# Altium schematic sheet Amplifier.SchDoc(Amplifier)
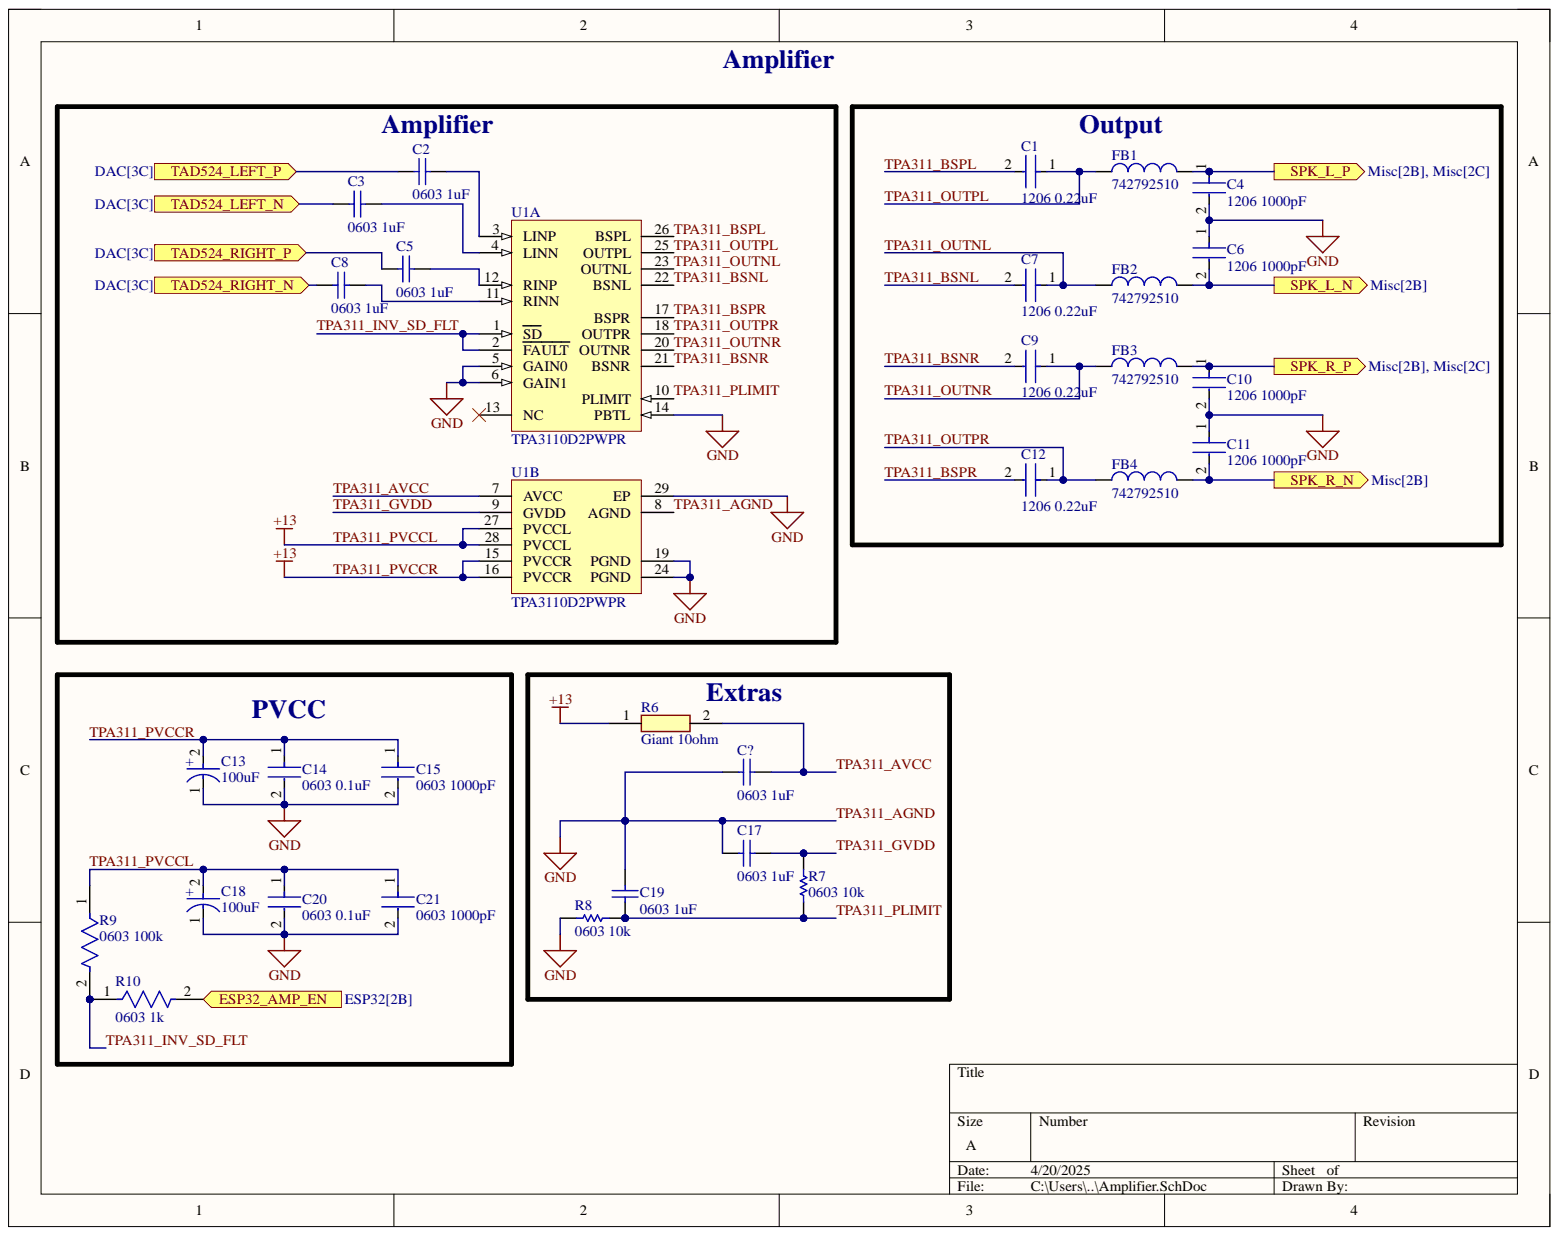
[diagram p0: Amplifier.SchDoc]

## Parts
C1  1206 0.22uF  [FP-C1206C-EB-MFG]
C2  0603 1uF  [CAPC1608X87X35LL15T15]
C3  0603 1uF  [CAPC1608X87X35LL15T15]
C4  1206 1000pF  [FP-C1206C-EB-MFG]
C5  0603 1uF  [CAPC1608X87X35LL15T15]
C6  1206 1000pF  [FP-C1206C-EB-MFG]
C7  1206 0.22uF  [FP-C1206C-EB-MFG]
C8  0603 1uF  [CAPC1608X87X35LL15T15]
C9  1206 0.22uF  [FP-C1206C-EB-MFG]
C10  1206 1000pF  [FP-C1206C-EB-MFG]
C11  1206 1000pF  [FP-C1206C-EB-MFG]
C12  1206 0.22uF  [FP-C1206C-EB-MFG]
C13  100uF  [FP-RAD-TH-D_8_0_5-L_11_5-MFG]
C14  0603 0.1uF  [FP-C0603C-CF-MFG]
C15  0603 1000pF  [FP-C0603C-CF-MFG]
C17  0603 1uF  [CAPC1608X87X35LL15T15]
C18  100uF  [FP-RAD-TH-D_8_0_5-L_11_5-MFG]
C19  0603 1uF  [CAPC1608X87X35LL15T15]
C20  0603 0.1uF  [FP-C0603C-CF-MFG]
C21  0603 1000pF  [FP-C0603C-CF-MFG]
C?  0603 1uF  [CAPC1608X87X35LL15T15]
FB1  742792510  [WE-CBF_1812_L4.5W3.2H1.5_HC]
FB2  742792510  [WE-CBF_1812_L4.5W3.2H1.5_HC]
FB3  742792510  [WE-CBF_1812_L4.5W3.2H1.5_HC]
FB4  742792510  [WE-CBF_1812_L4.5W3.2H1.5_HC]
R6  Giant 10ohm  [TO508P350X1040X2030-2P]
R7  0603 10k  Case Code (Imperial): 0603  [RESC1609X55X30LL15T15]
R8  0603 10k  Case Code (Imperial): 0603  [RESC1609X55X30LL15T15]
R9  0603 100k  Case Code (Imperial): 0603  [FP-RC0603-0_55-MFG]
R10  0603 1k  Case Code (Imperial): 0603  [FP-AC0603-IPC_B]
U1  TPA3110D2PWPR  [TI-PWP28-5720X1950TP_L]  (parts A,B)

## Ports
ESP32_AMP_EN, SPK_L_N, SPK_L_P, SPK_R_N, SPK_R_P, TAD524_LEFT_N, TAD524_LEFT_P, TAD524_RIGHT_N, TAD524_RIGHT_P

## Nets
ESP32_AMP_EN: R10.2 ; port ESP32_AMP_EN
SPK_L_N: C6.2 FB2.2 ; port SPK_L_N
SPK_L_P: C4.1 FB1.2 ; port SPK_L_P
SPK_R_N: C11.2 FB4.2 ; port SPK_R_N
SPK_R_P: C10.1 FB3.2 ; port SPK_R_P
TAD524_LEFT_N: C3.1 ; port TAD524_LEFT_N
TAD524_LEFT_P: C2.1 ; port TAD524_LEFT_P
TAD524_RIGHT_N: C8.1 ; port TAD524_RIGHT_N
TAD524_RIGHT_P: C5.1 ; port TAD524_RIGHT_P
TPA311_AGND: C4.2 C6.1 C10.2 C11.1 C13.1 C14.2 C15.2 C17.1 C18.1 C19.1 C20.2 C21.2 C?.1 R8.1 U1.5(GAIN0) U1.6(GAIN1) U1.8(AGND) U1.14(PBTL) U1.19(PGND) U1.24(PGND) U1.29(EP)
TPA311_AVCC: C?.2 R6.2 U1.7(AVCC)
TPA311_BSNL: C7.2 U1.22(BSNL)
TPA311_BSNR: C9.2 U1.21(BSNR)
TPA311_BSPL: C1.2 U1.26(BSPL)
TPA311_BSPR: C12.2 U1.17(BSPR)
TPA311_GVDD: C17.2 R7.1 U1.9(GVDD)
TPA311_INV_SD_FLT: R9.2 R10.1 U1.1(SD) U1.2(FAULT)
TPA311_OUTNL: C7.1 FB2.1 U1.23(OUTNL)
TPA311_OUTNR: C9.1 FB3.1 U1.20(OUTNR)
TPA311_OUTPL: C1.1 FB1.1 U1.25(OUTPL)
TPA311_OUTPR: C12.1 FB4.1 U1.18(OUTPR)
TPA311_PLIMIT: C19.2 R7.2 R8.2 U1.10(PLIMIT)
TPA311_PVCCL: C13.2 C14.1 C15.1 C18.2 C20.1 C21.1 R6.1 R9.1 U1.15(PVCCR) U1.16(PVCCR) U1.27(PVCCL) U1.28(PVCCL)
NetC2_2: C2.2 U1.3(LINP)
NetC3_2: C3.2 U1.4(LINN)
NetC5_2: C5.2 U1.12(RINP)
NetC8_2: C8.2 U1.11(RINN)

Not connected: U1 13(NC)

## Library data of the parts
C1 C7 C9 C12 = CMP-03020-014280-1: CAP CER 0.22UF 50V X7R 1206; Manufacturer: KEMET; Manufacturer Part Number: C1206C224K5RACTU; Automotive Grade: Grade 0; Capacitance: 0.22 μF; Material: Ceramic; Temperature Coefficient: 15%; Tolerance: 0.1; Value: 0.22 μF; Automotive: Yes; Category: Cap; Component Kind: Standard; ComponentLink2Description: Datasheet; ComponentLink2URL: https://content.kemet.com/datasheets/KEM_C1007_X8R_ULTRA_150C_SMD.pdf; Device Class L1: Passive Components; Device Class L2: Capacitors; Device Class L3: Ceramic Capacitors; Digikey Description: CAP CER 0.22UF 50V X7R 1206; Height: 0.88mm; Ipc Land Pattern Name: CAPC320160X78; Lead Free: Yes; Library Name: Altium Content Vault; Octopart: https://octopart.com/c1206c224k5ractu-kemet-99129; Package: C1206C-EB; RoHS: TRUE; Temperature: -55°C to +150°C; Temperature Characteristic: X7R; Temperature Range High: +150°C; Temperature Range Low: -55°C; Voltage Rating: 50 V
C2 C3 C5 C8 C17 C19 C? = CMP-2006-03713-1: Manufacturer: Kemet; Part Number: C0603C105K3RACTU; Component Kind: Standard; Library Name: Altium Content Vault
C4 C6 C10 C11 = CMP-03020-011698-1: CAP CER 100PF 100V C0G/NP0 1206; Manufacturer: KEMET; Manufacturer Part Number: C1206C101J1GACTU; Automotive Grade: Grade 0; Material: Ceramic; Temperature Characteristic: C0G; Temperature Coefficient: 15%; Tolerance: 0.05; Automotive: Yes; Capacitance: 100 pF; Category: Cap; Component Kind: Standard; ComponentLink2Description: Datasheet; ComponentLink2URL: https://content.kemet.com/datasheets/KEM_C1007_X8R_ULTRA_150C_SMD.pdf; Device Class L1: Passive Components; Device Class L2: Capacitors; Device Class L3: Ceramic Capacitors; Digikey Description: CAP CER 100PF 100V C0G/NP0 1206; Height: 0.88mm; Ipc Land Pattern Name: CAPC320160X78; Lead Free: Yes; Library Name: Altium Content Vault; Mouser Description: Multilayer Ceramic Capacitors MLCC - SMD/SMT 100volts 100pF C0G 5%; Octopart: https://octopart.com/c1206c101j1gactu-kemet-98729; Package: C1206C-EB; RoHS: TRUE; Temperature: -55°C to +150°C; Temperature Range High: +150°C; Temperature Range Low: -55°C; Value: 100 pF; Voltage Rating: 100 V
C13 C18 = CMP-05427-002079-1: Aluminum Electrolytic Capacitors (Radial Lead Type) 100uF ±20% 50V; ESR (Equivalent Series Resistance): 61mR; Lead Diameter: 0.6mm; Lead/Base Style: Straight; Life (Hours): 6000Hour; Ripple Current: 870mA; Capacitance: 100uF; Case/Package: Radial; Component Kind: Standard; Construction: RADIAL; Contact Plating: Tin; Diameter: 8mm; Height: 11.5mm; Height - Seated (Max): 0.512inch; Impedance: 61mR; Lead Pitch: 3.5mm; Length: 11.5mm; Library Name: Altium Content Vault; Max Operating Temperature: 105°C; Min Operating Temperature: -40°C; Mount: Through Hole; Number of Pins: 2; Package Quantity: 200; Packaging: Bulk; Radiation Hardening: No; REACH SVHC: No SVHC; Ripple Current (AC): 870mA; RoHS Compliant: Yes; Series: FR; Termination: Radial; Tolerance: 20%; Voltage: 50V; Voltage Rating: 50V; Voltage Rating (DC): 50V
C14 C20 = CMP-2006-03057-2: CAP CER 0.1UF 25V X7R 0603; Manufacturer: KEMET; Manufacturer Part Number: C0603C104K3RACTU; Automotive Grade: Grade 1; Capacitance: 0.10 μF; Material: Ceramic; Temperature Coefficient: 30ppm/°C; Tolerance: 0.1; Value: 0.10 μF; Automotive: Yes; Category: Cap; Component Kind: Standard; ComponentLink2Description: Datasheet; ComponentLink2URL: https://api.kemet.com/component-edge/download/datasheet/C0603C100J5GACAUTO.pdf; Device Class L1: Passive Components; Device Class L2: Capacitors; Device Class L3: Ceramic Capacitors; Digikey Description: CAP CER 0.1UF 25V X7R 0603; Height: 0.87mm; Lead Free: Yes; Library Name: Altium Content Vault; Mouser Description: Multilayer Ceramic Capacitors MLCC - SMD/SMT 25volts 0.1uF X7R 10%; Octopart: https://octopart.com/c0603c104k3ractu-kemet-127541; Package: C0603C-CF; RoHS: TRUE; Temperature: -55°C to +125°C; Temperature Characteristic: X7R; Temperature Range High: +125°C; Temperature Range Low: -55°C; Voltage Rating: 25 V
C15 C21 = CMP-2006-03010-2: CAP CER 1000PF 25V 5% NP0 0603; Manufacturer: KEMET; Manufacturer Part Number: C0603C102J3GACTU; Automotive Grade: Grade 1; Capacitance: 1,000 pF; Material: Ceramic; Temperature Characteristic: C0G; Temperature Coefficient: 30ppm/°C; Tolerance: 0.05; Value: 1,000 pF; Automotive: Yes; Category: Cap; Component Kind: Standard; ComponentLink2Description: Datasheet; ComponentLink2URL: https://api.kemet.com/component-edge/download/datasheet/C0603C100J5GACAUTO.pdf; Device Class L1: Passive Components; Device Class L2: Capacitors; Device Class L3: Ceramic Capacitors; Digikey Description: CAP CER 1000PF 25V 5% NP0 0603; Height: 0.87mm; Lead Free: Yes; Library Name: Altium Content Vault; Mouser Description: Multilayer Ceramic Capacitors MLCC - SMD/SMT 25volts 1000pF C0G 5%; Octopart: https://octopart.com/c0603c102j3gactu-kemet-8375722; Package: C0603C-CF; RoHS: TRUE; Temperature: -55°C to +125°C; Temperature Range High: +125°C; Temperature Range Low: -55°C; Voltage Rating: 25 V
FB1-FB4 = CMP-0220-00085-2: NexarPartId: 7861697; Current Rating: 6A; DC Resistance (DCR): 30mΩ; Impedance: 70Ω; Material: Ferrite; Max DC Current: 3mA; Number of Lines: 1; Test Frequency: 100MHz; Tolerance: 25%; Case Code (Imperial): 1812; Case Code (Metric): 4532; Case/Package: SMD/SMT; Component Kind: Standard; Depth: 3.2mm; Height: 1.5mm; Lead Free: Lead Free; Length: 4.5mm; Library Name: Altium Content Vault; Max Current Rating: 6A; Max Operating Temperature: 125°C; Min Operating Temperature: -55°C; Mount: Surface Mount; Packaging: Cut Tape (CT); REACH SVHC: Unknown; Resistance: 30mΩ; RoHS: Compliant; Termination: SMD/SMT; Weight: 112.008966mg; Width: 3.2mm
R6 = LTO050F10R00JTE3: Resistor; Manufacturer_Name: Vishay; Arrow Part Number: LTO050F10R00JTE3; Arrow Price/Stock: https://www.arrow.com/en/products/lto050f10r00jte3/vishay?region=nac; Component Kind: Standard; Datasheet Link: https://componentsearchengine.com/Datasheets/2/LTO050F10R00JTE3.pdf; Height: 3.5mm; Manufacturer_Part_Number: LTO050F10R00JTE3; Mouser Part Number: 72-LTO050F10R00JTE3; Mouser Price/Stock: https://www.mouser.co.uk/ProductDetail/Vishay-Sfernice/LTO050F10R00JTE3?qs=YEvEAkYMKXtbfJC7MrgrfA%3D%3D
R7 R8 = CMP-1012-00623-3: Chip Resistor, 10 KOhm, +/- 1%, 0.1 W, -55 to 155 degC, 0603 (1608 Metric), RoHS, Tape and Reel; Manufacturer: Panasonic; Max Power Dissipation: 100mW; Resistance: 10KOhm; Temperature Coefficient: 100ppm\/degreesC; Voltage Rating: 75V; Case Code (Metric): 1608; Case\/Package: 0603; Component Kind: Standard; Composition: ThickFilm; Contact Plating: Tin; Datasheet URL: https://industrial.panasonic.com/cdbs/www-data/pdf/RDA0000/AOA0000C304.pdf; Datasheet Version: 02/2017; Depth: 0.8mm; Height: 0.45mm; Lead Free: LeadFree; Length: 1.6mm; Library Name: Altium Content Vault; Manufacturer URL: http://www.panasonic.com/; Max Operating Temperature: 155degC; Military Standard: Not; Min Operating Temperature: -55degC; Mount: SurfaceMount; Mounting Technology: SurfaceMount; Number of Pins: 2; Number of Terminations: 2; Package Description: 2-Pin Surface Mount Device, Body 1.6 x 0.85 mm; Package Quantity: 1; Package Reference: 603; Packaging: TapeandReel; Power Rating: 100mW; Radiation Hardening: No; REACH SVHC: NoSVHC; RoHSCompliant: true; Termination: SMD/SMT; Tolerance: 1%; Voltage Rating (DC): 75V; Width: 0.031inch
R9 = CMP-2100-03662-2: RES SMD 100K OHM 1% 1/10W 0603; Max Power Dissipation: 100mW; Resistance: 100kR; Temperature Coefficient: 100ppm/°C; Voltage Rating: 50V; Working Voltage: 75V; Case Code (Metric): 1608; Case/Package: 0603; Component Kind: Standard; Construction: Rectangular; Depth: 0.8mm; Features: Moisture Resistant; Height: 0.45mm; Lead Free: Lead Free; Length: 1.6mm; Library Name: Altium Content Vault; Max Operating Temperature: 155°C; Military Standard: Not; Min Operating Temperature: -55°C; Number of Pins: 2; Number of Terminations: 2; Packaging: Tape and Reel; Power Rating: 100mW; REACH SVHC: No SVHC; Resistance Tolerance: 1%; Resistor Type: Thick Film; RoHS Compliant: Yes; Series: RC; Technology: THICK FILM; Termination: SMD/SMT; Tolerance: 1%; Voltage Rating (DC): 50V; Width: 0.8mm
R10 = CMP-2000-04828-3: RES 1kΩ 1.00% 0.1W 0603; Max Power Dissipation: 100mW; Resistance: 1kR; Temperature Coefficient: 100ppm/°C; Tolerance: 1%; Voltage Rating: 50V; Case Code (Metric): 1608; Case/Package: 0603; Component Kind: Standard; Construction: Rectangular; Depth: 0.8mm; Features: Moisture Resistant; Height: 0.022inch; Lead Free: Lead Free; Length: 0.063inch; Library Name: Altium Content Vault; Max Operating Temperature: 155°C; Military Standard: Not; Min Operating Temperature: -55°C; Number of Terminations: 2; Package Quantity: 1; Packaging: Tape and Reel; Power Rating: 100mW; Ratings: AEC-Q200; Resistor Type: Thick Film; RoHS Compliant: Yes; Series: AC; Size Code: 0603; Termination: SMD/SMT; Width: 0.031inch
U1 = CMP-0994-00255-1: 15 W Filter-Free Class D Stereo Amplifier with SpeakerGuard(TM), -40 to 85 degC, 28-pin SOP (PWP28), Green (RoHS & no Sb/Br); Manufacturer: Texas Instruments; Analog Supply Max [V]: 26; Analog Supply Min [V]: 8; Input Type: Analog; Max. Number of Speaker Outputs: 2; SubFamily: Mid/High-Power Amplifier; Code_JEDEC: MO-153; Component Kind: Standard; ComponentLink1Description: Manufacturer URL; ComponentLink1URL: http://www.ti.com/; ComponentLink2Description: Datasheet; ComponentLink2URL: http://www.ti.com/general/docs/lit/getliterature.tsp?genericPartNumber=TPA3110D2&fileType=pdf; ComponentLink3Description: Package Specification; ComponentLink3URL: http://www.ti.com/litv/pdf/mpds373; DatasheetVersion: SLOS528D; Eco-Plan: Green (RoHS & no Sb/Br); Library Name: Altium Content Vault; Mounting Technology: Surface Mount; PackageDescription: 28-Pin Plastic Small Outline, Body 4.4 x 9.7 mm, Pitch 0.65 mm; PackageReference: PWP28-5720X1950TP; PackageVersion: 4073225-6/I, 05/2011; PartNumber: TPA3110D2PWPR; Power Stage Supply Min(V): 8
